# Altium schematic sheet BSPD_CMOS.SchDoc
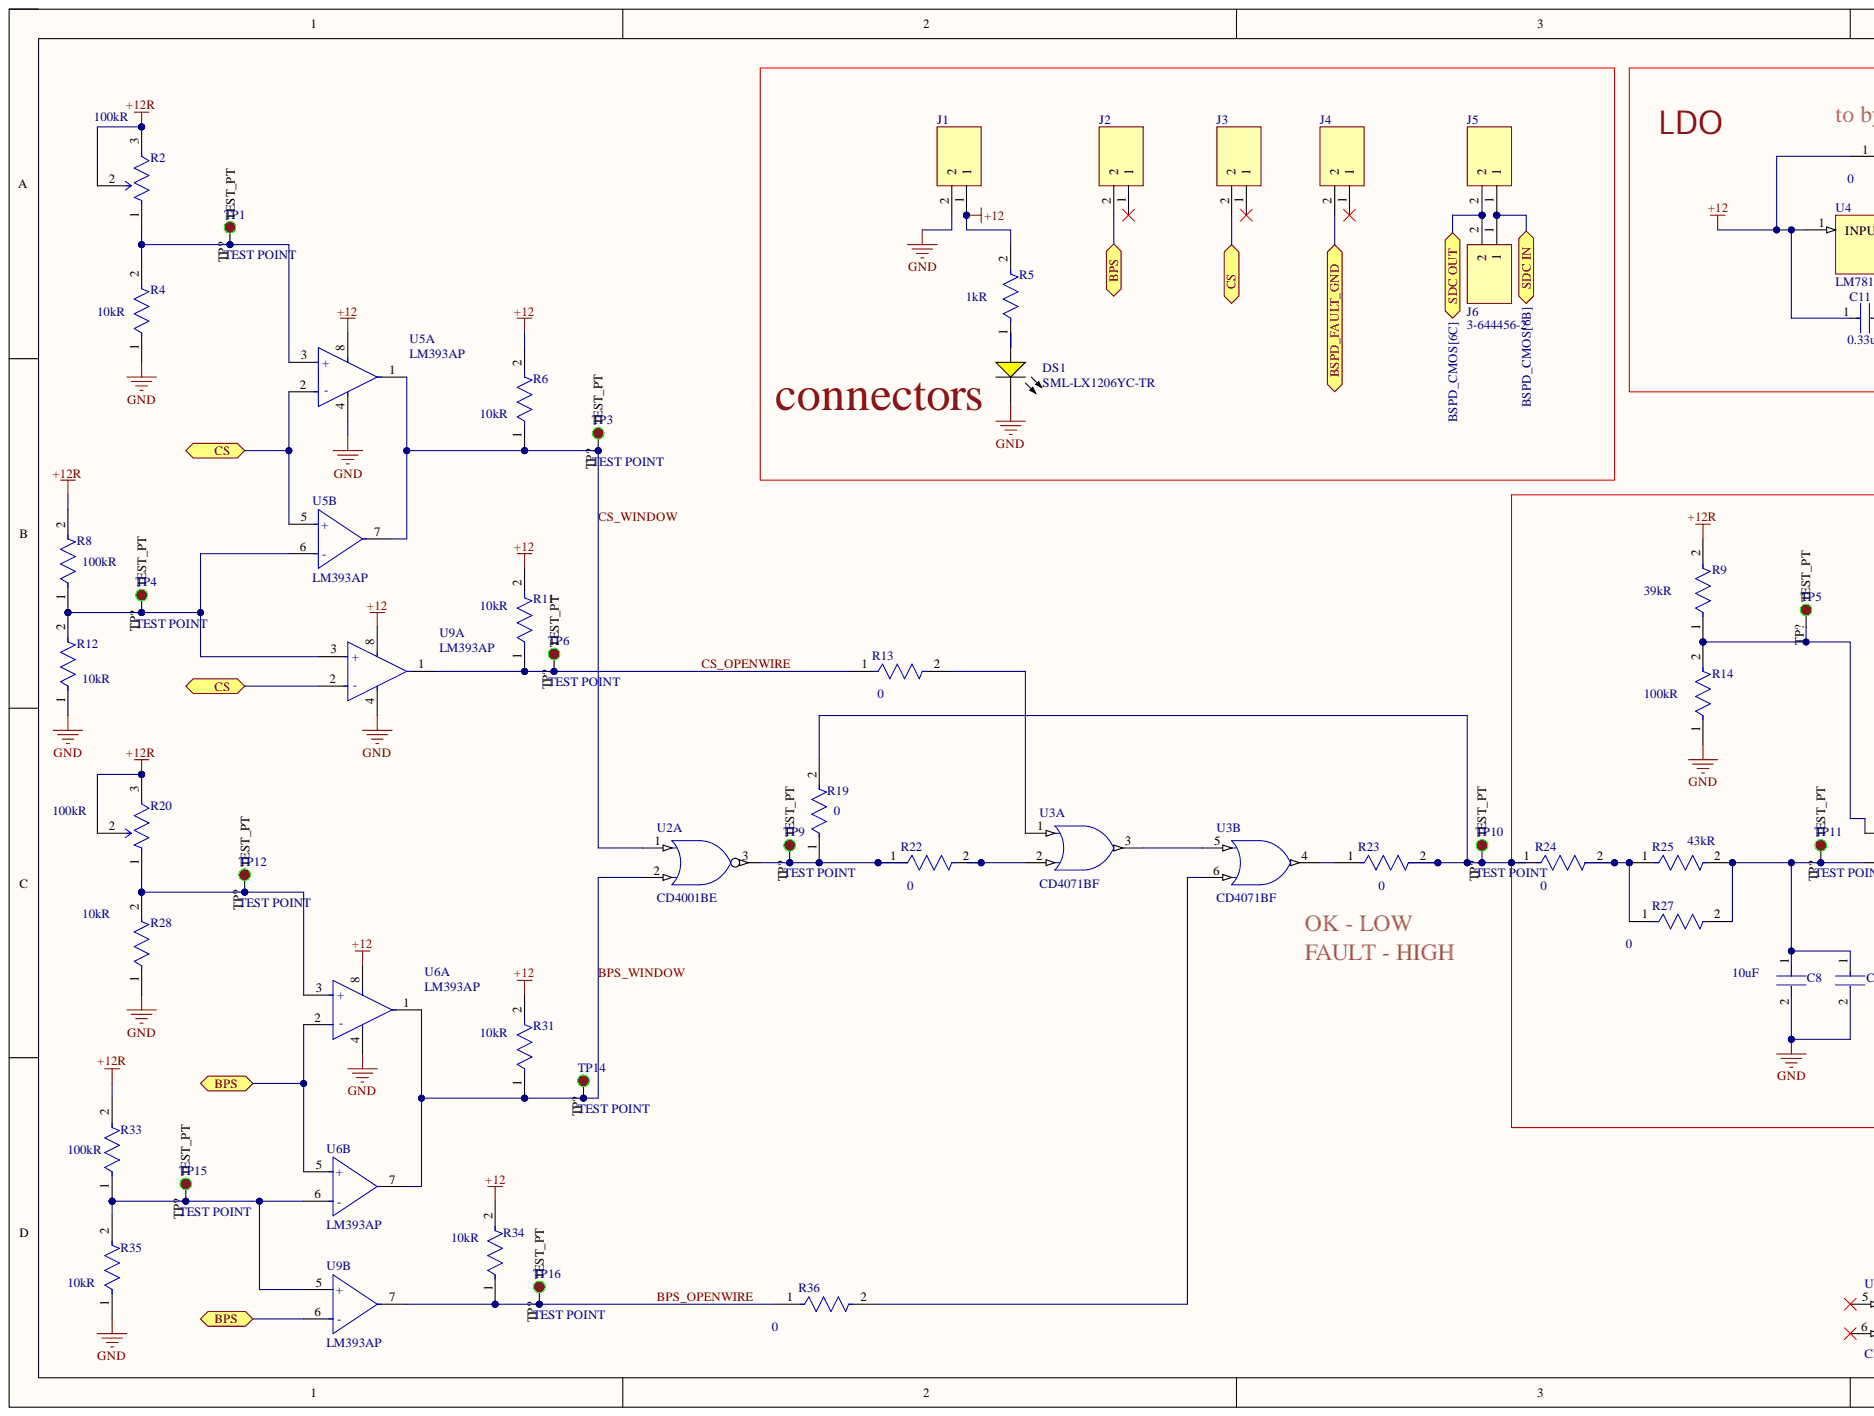
[diagram p0: BSPD_CMOS.SchDoc, left]
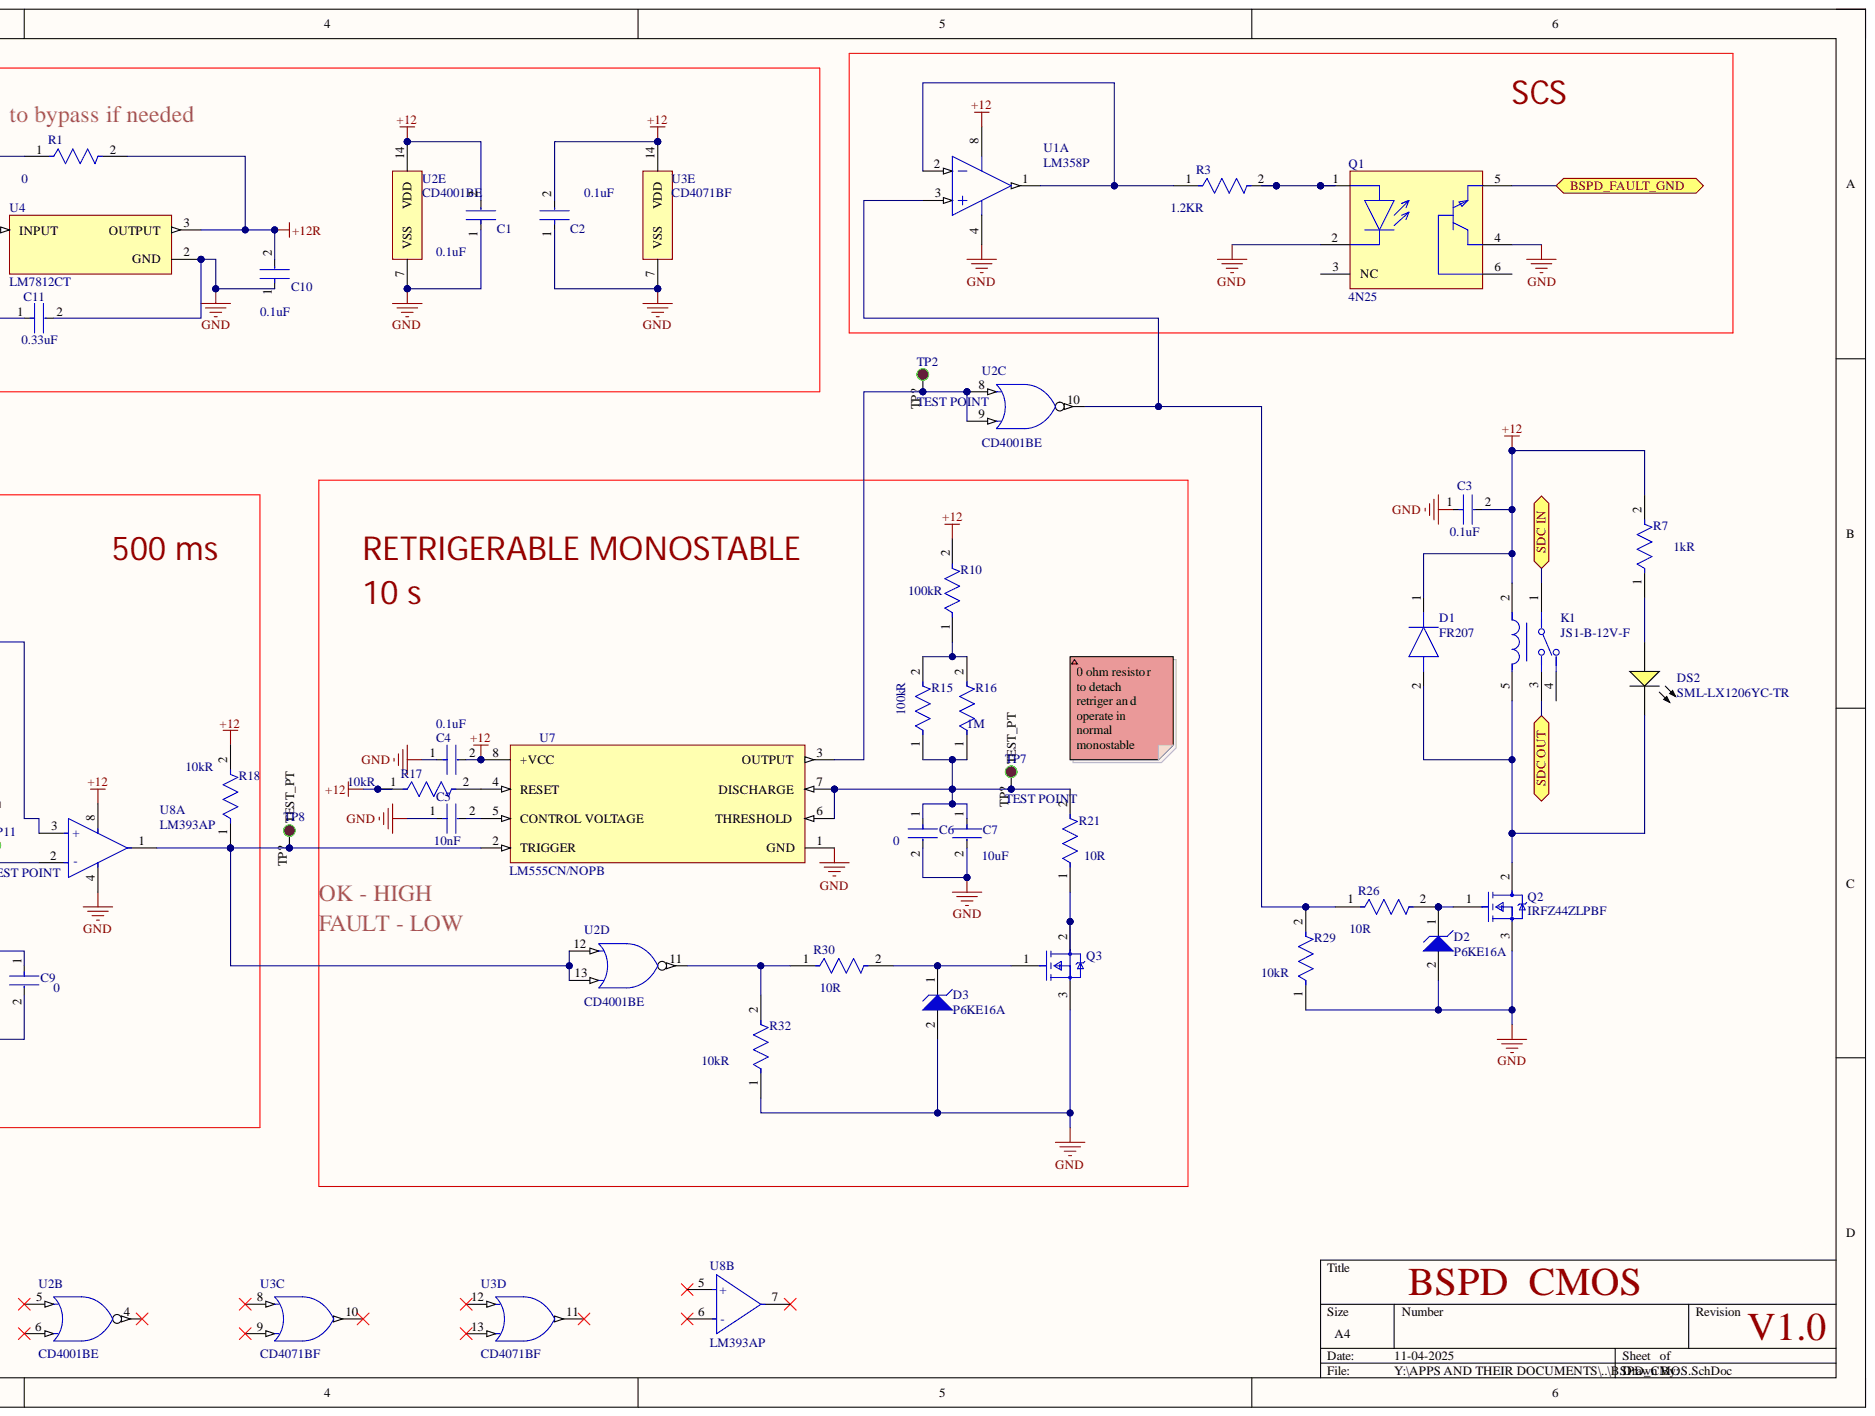
[diagram p1: BSPD_CMOS.SchDoc, right]

## Parts
C1  Capacitance: 0.1uF  [FP-0805-L_2_01_0_2-W_1_25-MFG]  (hidden comment: 08051C224K4T2A)
C2  Capacitance: 0.1uF  [FP-0805-L_2_01_0_2-W_1_25-MFG]  (hidden comment: 08051C224K4T2A)
C3  Capacitance: 0.1uF  [FP-0805-L_2_01_0_2-W_1_25-MFG]  (hidden comment: 08051C224K4T2A)
C4  Capacitance: 0.1uF  [FP-0805-L_2_01_0_2-W_1_25-MFG]  (hidden comment: 08051C224K4T2A)
C5  Capacitance: 10nF  [FP-0805-L_2_01_0_2-W_1_25-MFG]  (hidden comment: 08051C224K4T2A)
C6  08051C224K4T2A  [FP-0805-L_2_01_0_2-W_1_25-MFG]  (value not drawn)
C7  Capacitance: 10uF  [FP-0805-L_2_01_0_2-W_1_25-MFG]  (hidden comment: 08051C224K4T2A)
C8  Capacitance: 10uF  [FP-0805-L_2_01_0_2-W_1_25-MFG]  (hidden comment: 08051C224K4T2A)
C9  08051C224K4T2A  [FP-0805-L_2_01_0_2-W_1_25-MFG]  (value not drawn)
C10  Capacitance: 0.1uF  [FP-0805-L_2_01_0_2-W_1_25-MFG]  (hidden comment: 08051C224K4T2A)
C11  Capacitance: 0.33uF  [FP-0805-L_2_01_0_2-W_1_25-MFG]  (hidden comment: 08051C224K4T2A)
D1  FR207  [DIOAD1910W90L670D310]
D2  P6KE16A  [ONSC-SURMETIC40-2-017AA-01]
D3  P6KE16A  [ONSC-SURMETIC40-2-017AA-01]
DS1  SML-LX1206YC-TR  [LUMX-SML-LX1206YC-TR_V]
DS2  SML-LX1206YC-TR  [LUMX-SML-LX1206YC-TR_V]
J1  39-30-1020  [39-30-102Y]  (value not drawn)
J2  39-30-1020  [39-30-102Y]  (value not drawn)
J3  39-30-1020  [39-30-102Y]  (value not drawn)
J4  39-30-1020  [39-30-102Y]  (value not drawn)
J5  39-30-1020  [39-30-102Y]  (value not drawn)
J6  3-644456-2  [HDRV2W67P0X254_1X2_478X234X1029P]
K1  JS1-B-12V-F  [FP-JS1-B-12V-F-MFG]
Q1  4N25  [FP-4N25-MFG]
Q2  IRFZ44ZLPBF  [FP-PG-TO262-3-MFG]
Q3  IRFZ44ZLPBF  [FP-PG-TO262-3-MFG]
R1  MCU0805MD1003DP500  [FP-MCU0805-IPC_B]  (value not drawn)
R2  Resistance: 100kR  [FP-3386P-MFG]  (hidden comment: 3386P-1-104LF)
R3  Resistance: 1.2KR  [FP-MCU0805-IPC_B]  (hidden comment: MCU0805MD1003DP500)
R4  Resistance: 10kR  [FP-MCU0805-IPC_B]  (hidden comment: MCU0805MD1003DP500)
R5  Resistance: 1kR  [FP-MCU0805-IPC_B]  (hidden comment: MCU0805MD1003DP500)
R6  Resistance: 10kR  [FP-MCU0805-IPC_B]  (hidden comment: MCU0805MD1003DP500)
R7  Resistance: 1kR  [FP-MCU0805-IPC_B]  (hidden comment: MCU0805MD1003DP500)
R8  Resistance: 100kR  [FP-MCU0805-IPC_B]  (hidden comment: MCU0805MD1003DP500)
R9  Resistance: 39kR  [FP-MCU0805-IPC_B]  (hidden comment: MCU0805MD1003DP500)
R10  Resistance: 100kR  [FP-MCU0805-IPC_B]  (hidden comment: MCU0805MD1003DP500)
R11  Resistance: 10kR  [FP-MCU0805-IPC_B]  (hidden comment: MCU0805MD1003DP500)
R12  Resistance: 10kR  [FP-MCU0805-IPC_B]  (hidden comment: MCU0805MD1003DP500)
R13  MCU0805MD1003DP500  [FP-MCU0805-IPC_B]  (value not drawn)
R14  Resistance: 100kR  [FP-MCU0805-IPC_B]  (hidden comment: MCU0805MD1003DP500)
R15  Resistance: 100kR  [FP-MCU0805-IPC_B]  (hidden comment: MCU0805MD1003DP500)
R16  Resistance: 1M  [FP-MCU0805-IPC_B]  (hidden comment: MCU0805MD1003DP500)
R17  Resistance: 10kR  [FP-MCU0805-IPC_B]  (hidden comment: MCU0805MD1003DP500)
R18  Resistance: 10kR  [FP-MCU0805-IPC_B]  (hidden comment: MCU0805MD1003DP500)
R19  MCU0805MD1003DP500  [FP-MCU0805-IPC_B]  (value not drawn)
R20  Resistance: 100kR  [FP-3386P-MFG]  (hidden comment: 3386P-1-104LF)
R21  Resistance: 10R  [FP-MCU0805-IPC_B]  (hidden comment: MCU0805MD1003DP500)
R22  MCU0805MD1003DP500  [FP-MCU0805-IPC_B]  (value not drawn)
R23  MCU0805MD1003DP500  [FP-MCU0805-IPC_B]  (value not drawn)
R24  MCU0805MD1003DP500  [FP-MCU0805-IPC_B]  (value not drawn)
R25  Resistance: 43kR  [FP-MCU0805-IPC_B]  (hidden comment: MCU0805MD1003DP500)
R26  Resistance: 10R  [FP-MCU0805-IPC_B]  (hidden comment: MCU0805MD1003DP500)
R27  MCU0805MD1003DP500  [FP-MCU0805-IPC_B]  (value not drawn)
R28  Resistance: 10kR  [FP-MCU0805-IPC_B]  (hidden comment: MCU0805MD1003DP500)
R29  Resistance: 10kR  [FP-MCU0805-IPC_B]  (hidden comment: MCU0805MD1003DP500)
R30  Resistance: 10R  [FP-MCU0805-IPC_B]  (hidden comment: MCU0805MD1003DP500)
R31  Resistance: 10kR  [FP-MCU0805-IPC_B]  (hidden comment: MCU0805MD1003DP500)
R32  Resistance: 10kR  [FP-MCU0805-IPC_B]  (hidden comment: MCU0805MD1003DP500)
R33  Resistance: 100kR  [FP-MCU0805-IPC_B]  (hidden comment: MCU0805MD1003DP500)
R34  Resistance: 10kR  [FP-MCU0805-IPC_B]  (hidden comment: MCU0805MD1003DP500)
R35  Resistance: 10kR  [FP-MCU0805-IPC_B]  (hidden comment: MCU0805MD1003DP500)
R36  MCU0805MD1003DP500  [FP-MCU0805-IPC_B]  (value not drawn)
TP1  TEST POINT  [TEST_POINT]
TP2  TEST POINT  [TEST_POINT]
TP3  TEST POINT  [TEST_POINT]
TP4  TEST POINT  [TEST_POINT]
TP5  TEST POINT  [TEST_POINT]
TP6  TEST POINT  [TEST_POINT]
TP7  TEST POINT  [TEST_POINT]
TP8  TEST POINT  [TEST_POINT]
TP9  TEST POINT  [TEST_POINT]
TP10  TEST POINT  [TEST_POINT]
TP11  TEST POINT  [TEST_POINT]
TP12  TEST POINT  [TEST_POINT]
TP14  TEST POINT  [TEST_POINT]
TP15  TEST POINT  [TEST_POINT]
TP16  TEST POINT  [TEST_POINT]
U1  LM358P  [P0008A]  (part A)
U2  CD4001BE  [N0014A]  (parts A,B,C,D,E)
U3  CD4071BF  [J0014A]  (parts A,B,C,D,E)
U4  LM7812CT  [FP-TO220B03-MFG]
U5  LM393AP  [FP-P0008A-MFG]  (parts A,B)
U6  LM393AP  [FP-P0008A-MFG]  (parts A,B)
U7  LM555CN/NOPB  [FP-P0008A-MFG]
U8  LM393AP  [FP-P0008A-MFG]  (parts A,B)
U9  LM393AP  [FP-P0008A-MFG]  (parts A,B)

## Ports
BPS, BSPD_FAULT_GND, CS, SDC IN, SDC OUT

## Nets
+12: C1.2 C2.2 C3.2 C4.2 C11.1 D1.1 J1.1 K1.2 R1.1 R5.2 R6.2 R7.2 R10.2 R11.2 R17.1 R18.2 R31.2 R34.2 U1.8 U2.14(VDD) U3.14(VDD) U4.1 U5.8 U6.8 U7.8(+VCC) U8.8 U9.8
+12R: C10.2 R1.2 R2.2 R2.3 R8.2 R9.2 R20.2 R20.3 R33.2 U4.3
GND: C1.1 C2.1 C3.1 C4.1 C5.1 C6.2 C7.2 C8.2 C9.2 C10.1 C11.2 D2.2 D3.2 DS1.2 J1.2 Q1.2 Q1.4 Q2.3 Q3.3 R4.1 R12.1 R14.1 R28.1 R29.1 R32.1 R35.1 U1.4 U2.7(VSS) U3.7(VSS) U4.2 U5.4 U6.4 U7.1(GND) U8.4 U9.4
BPS: J2.2 U6.2(-) U6.5(+) U9.6(-) ; port BPS
BPS_OPENWIRE: R34.1 R36.1 TP16.TP? U9.7
BPS_WINDOW: R31.1 TP14.TP? U2.2 U6.1 U6.7
BSPD_FAULT_GND: J4.2 Q1.5 ; port BSPD_FAULT_GND
CS: J3.2 U5.2(-) U5.5(+) U9.2(-) ; port CS
CS_OPENWIRE: R11.1 R13.1 TP6.TP? U9.1
CS_WINDOW: R6.1 TP3.TP? U2.1 U5.1 U5.7
SDC IN: J5.1 J6.1 K1.1 ; port SDC IN
SDC OUT: J5.2 J6.2 K1.3 ; port SDC OUT
NetC5_2: C5.2 U7.5(CONTROL_VOLTAGE)
NetC6_1: C6.1 C7.1 R15.1 R16.1 R21.2 TP7.TP? U7.6(THRESHOLD) U7.7(DISCHARGE)
NetC8_1: C8.1 C9.1 R25.2 R27.2 TP11.TP? U8.2(-)
NetD1_2: D1.2 DS2.2 K1.5 Q2.2
NetD2_1: D2.1 Q2.1 R26.2
NetD3_1: D3.1 Q3.1 R30.2
NetDS1_1: DS1.1 R5.1
NetDS2_1: DS2.1 R7.1
NetQ1_1: Q1.1 R3.2
NetQ3_2: Q3.2 R21.1
NetR2_1: R2.1 R4.2 TP1.TP? U5.3(+)
NetR3_1: R3.1 U1.1 U1.2
NetR8_1: R8.1 R12.2 TP4.TP? U5.6(-) U9.3(+)
NetR9_1: R9.1 R14.2 TP5.TP? U8.3(+)
NetR10_1: R10.1 R15.2 R16.2
NetR13_2: R13.2 U3.1
NetR17_2: R17.2 U7.4(RESET)
NetR18_1: R18.1 TP8.TP? U2.12 U2.13 U7.2(TRIGGER) U8.1
NetR19_1: R19.1 R22.1 TP9.TP? U2.3
NetR19_2: R19.2 R23.2 R24.1 TP10.TP?
NetR20_1: R20.1 R28.2 TP12.TP? U6.3(+)
NetR22_2: R22.2 U3.2
NetR23_1: R23.1 U3.4
NetR24_2: R24.2 R25.1 R27.1
NetR26_1: R26.1 R29.2 U1.3 U2.10
NetR30_1: R30.1 R32.2 U2.11
NetR33_1: R33.1 R35.2 TP15.TP? U6.6(-) U9.5(+)
NetR36_2: R36.2 U3.6
NetTP2_TP?: TP2.TP? U2.8 U2.9 U7.3(OUTPUT)
NetU3_3: U3.3 U3.5

Not connected: J2 1; J3 1; J4 1; K1 4; Q1 3(NC) 6; U2 4 5 6; U3 8 9 10 11 12 13; U8 5(+) 6(-) 7

## Library data of the parts
C1-C5 C7 C8 C10 C11 = CMP-04427-012849-1: Automotive Ceramic Capacitor, 0805, 220nF, 10%, X7R, 15%, 100V; Case/Package: 0805; Component Kind: Standard; Contact Plating: Tin; Dielectric: X7R; Length: 2.01mm; Library Name: Altium Content Vault; Max Operating Temperature: 125°C; Min Operating Temperature: -55°C; Mount: Surface Mount; RoHS Compliant: Yes; Termination: Wraparound; Tolerance: 10%; Voltage Rating (DC): 100V
C6 C9 = CMP-04427-012849-1: Automotive Ceramic Capacitor, 0805, 220nF, 10%, X7R, 15%, 100V; Capacitance: 0; Case/Package: 0805; Component Kind: Standard; Contact Plating: Tin; Dielectric: X7R; Length: 2.01mm; Library Name: Altium Content Vault; Max Operating Temperature: 125°C; Min Operating Temperature: -55°C; Mount: Surface Mount; RoHS Compliant: Yes; Termination: Wraparound; Tolerance: 10%; Voltage Rating (DC): 100V
D1 = FR207: Diode; Manufacturer_Name: Rectron; Arrow Part Number: FR207; Arrow Price/Stock: https://www.arrow.com/en/products/fr207/rectron?region=nac; Component Kind: Standard; Datasheet Link: https://www.arrow.com/en/products/fr207/rectron; Manufacturer_Part_Number: FR207
D2 D3 = CMP-1061-00713-1: 600 W Peak Power Transient Voltage Suppressor, 2-Pin Surmetic(TM) 40, Bulk Box; Manufacturer: On Semiconductor; Direction: Unidirectional; IR Max (uA): 5; PPK Max (W): 600; V(BR) Typ (V): 16; Component Kind: Standard; ComponentLink1Description: Manufacturer URL; ComponentLink1URL: http://www.onsemi.com/; ComponentLink2Description: Datasheet; ComponentLink2URL: http://www.onsemi.com/pub_link/Collateral/P6KE6.8A-D.PDF; ComponentLink3Description: Package Specification; ComponentLink3URL: http://www.onsemi.cn/pub_link/Collateral/017AA.PDF; DatasheetVersion: Rev. 10; Library Name: Altium Content Vault; Mounting Technology: Through Hole; PackageDescription: 2-Pin, Axial Lead, Diameter 3.49 mm; PackageReference: SURMETIC40-2-017AA-01; PackageVersion: Rev. O, 09/2005; Packing: Bulk Box; PartNumber: P6KE16A
DS1 DS2 = CMP-2000-07124-1: Yellow LED, Water Clear Len, 585 nm, 2.1 V, -40 to 85 degC, 2-Pin SMD, RoHS, Tape and Reel; Manufacturer: Lumex; Catalog Drawings: SML-LX1206SeriesTopSML-LX1206SeriesSideSML-LX1206SeriesBottomSML-LX1206SeriesFootprint; Current - Test: 20mA; Lens Color: Colorless; Lens Style/Size: RectanglewithFlatTop; Millicandela Rating: 6mcd; Online Catalog: SML-LX1206RectanglewithFlatTop1206PackageLEDs; Other Names: 67-1358-2LU60357TRSMLLX1206YCTR; Product Training Modules: LEDsBenefitsofCustomLEDandLCDTechnology; Viewing Angle: 160°; Voltage - Forward (Vf) (Typ): 2.1V; Wavelength - Peak: 585nm; Category: Optoelectronics; Color: Yellow; Component Kind: Standard; Datasheet URL: http://www.lumex.com/content/files/ProductAttachment/SML-LX1206YC-TR.pdf; Datasheet Version: Rev. K, 08/2012; Family: LEDIndication-Discrete; Features: -; Height (Max): 1.10mm; Lens Transparency: Clear; Library Name: Altium Content Vault; Manufacturer URL: http://www.lumexinstruments.com/; Mounting Technology: SurfaceMount; Mounting Type: SurfaceMount; Package / Case: 1206(3216Metric); Package Description: 2-Pin Surface Mount Device, Body 3.2 x 1.5 mm; Package Reference: SML-LX1206YC-TR; Packaging: Tape&Reel(TR); RoHS Information: RoHSCertofCompliance; Series: -; Size / Dimension: 3.20mmLx1.60mmW; Standard Package: 3,000; Supplier Device Package: 1206; Wavelength - Dominant: -
J1-J5 = 39-30-1020: Connector; Manufacturer_Name: Molex; Component Kind: Standard; Datasheet Link: https://www.molex.com/pdm_docs/sd/039300020_sd.pdf; Height: 10mm; Manufacturer_Part_Number: 39-30-1020; Mouser Part Number: 538-39-30-1020; Mouser Price/Stock: https://www.mouser.co.uk/ProductDetail/Molex/39-30-1020?qs=tRPrwvvr%2Fuj45jNVCpHEJA%3D%3D
J6 = 3-644456-2: Connector; Manufacturer_Name: TE Connectivity; Arrow Part Number: 3-644456-2; Arrow Price/Stock: https://www.arrow.com/en/products/3-644456-2/te-connectivity?utm_currency=USD&region=nac; Component Kind: Standard; Datasheet Link: https://www.te.com/commerce/DocumentDelivery/DDEController?Action=showdoc&DocId=Customer+Drawing%7F644456%7FD2%7Fpdf%7FEnglish%7FENG_CD_644456_D2.pdf%7F3-644456-2; Height: 10.287mm; Manufacturer_Part_Number: 3-644456-2; Mouser Part Number: 571-3-644456-2; Mouser Price/Stock: https://www.mouser.co.uk/ProductDetail/TE-Connectivity/3-644456-2?qs=AplfTeSvkkCnnz4NxZTwTQ%3D%3D
K1 = CMP-05607-000050-1: RELAY GEN PURPOSE SPDT 10A 12V; Coil Current: 30mA; Coil Power: 360mW; Coil Resistance: 400R; Coil Type: Non-Latching; Max Voltage Rating (AC): 250V; Max Voltage Rating (DC): 100V; Operate Time: 10ms; Relay Type: General Purpose; Coil Voltage (DC): 12V; Component Kind: Standard; Contact Current Rating: 10A; Contact Material: Tin; Depth: 16mm; Height: 16mm; Lead Free: Lead Free; Length: 22mm; Library Name: Altium Content Vault; Max Operating Temperature: 85°C; Min Operating Temperature: -40°C; Mount: Through Hole; Number of Pins: 5; Package Quantity: 100; Packaging: Bulk; Power Consumption: 360mW; Power Rating: 360mW; Release Time: 10ms; Series: JS; Termination: PC Pin; Throw Configuration: SPDT; Voltage Rating (AC): 250V; Width: 22mm
Q1 = CMP-22018-000009-1: OPTOISO 2.5KV TRANS W/BASE 6DIP; Collector Emitter Breakdown Voltage: 30V; Collector Emitter Saturation Voltage: 500mV; Current Transfer Ratio: 50%; Fall Time: 2us; Forward Current: 60mA; Forward Voltage: 1.5V; Input Current: 50mA; Input Type: DC; Isolation Voltage: 5kV; Max Collector Current: 100mA; Max Input Current: 80mA; Max Power Dissipation: 250mW; Number of Channels: 1; Output Voltage: 70V; Power Dissipation: 150mW; Reverse Breakdown Voltage: 5V; Case/Package: PDIP; Collector Emitter Voltage (VCEO): 30V; Collector-emitter Voltage-Max: 500mV; Component Kind: Standard; Isolation Voltage-Max: 5kV; Lead Free: Lead Free; Library Name: Altium Content Vault; Max Operating Temperature: 100°C; Max Output Voltage: 30V; Min Operating Temperature: -55°C; Mount: Through Hole; Number of Circuits: 1; Number of Elements: 1; Number of Pins: 6; Output Current per Channel: 100mA; Package Quantity: 2000; Packaging: Rail/Tube; Radiation Hardening: No; Rise Time: 2us; Series: -
Q2 Q3 = CMP-32901-000428-1: MOSFET N-CH 55V 51A TO262; Drain to Source Resistance: 13.9mR; Element Configuration: Single; Gate to Source Voltage (Vgs): 20V; Input Capacitance: 1.42nF; Max Power Dissipation: 80W; Turn-Off Delay Time: 33ns; Turn-On Delay Time: 14ns; Case/Package: TO-262; Component Kind: Standard; Continuous Drain Current (ID): 51A; Drain to Source Voltage (Vdss): 55V; Halogen Free: Halogen Free; Height: 0.355inch; Lead Free: Contains Lead; Length: 0.419inch; Library Name: Altium Content Vault; Max Dual Supply Voltage: 55V; Max Operating Temperature: 175°C; Min Operating Temperature: -55°C; Mount: Through Hole; Number of Pins: 3; Packaging: Rail/Tube; Power Dissipation: 80W; Rds On Max: 13.9mR; RoHS Compliant: Yes; Width: 0.19inch
R1 R13 R19 R22-R24 R27 R36 = CMP-02407-011860-1: RES 1.05K OHM 0.5% 1/5W 0805; Max Power Dissipation: 200mW; Resistance: 0; Temperature Coefficient: 25ppm/°C; Voltage Rating: 150V; Case Code (Imperial): 0805; Case Code (Metric): 2012; Case/Package: 0805; Component Kind: Standard; Features: Automotive AEC-Q200; Height: 0.024inch; Length: 0.079inch; Library Name: Altium Content Vault; Max Operating Temperature: 175°C; Min Operating Temperature: -55°C; Number of Terminations: 2; Package Quantity: 1; Packaging: Tape and Reel; Power Rating: 200mW; Resistor Type: Thin Film; RoHS Compliant: Yes; Series: MCU 0805 - Professional; Tolerance: 0.5%; Weight: 0.000162oz; Width: 1.25mm
R2 R20 = CMP-2000-05592-8: TRIMMER 100K OHM 0.5W PC PIN TOP; Manufacturer Series: 3386; Actuator Orientation: Vertical; Actuator Type: SCREW; Max Power Dissipation: 500mW; Number of Terminals/Pins: 3; Number of Turns: 1; Resistance Law: Linear; Resistance Tolerance: 10%; Temperature Coefficient: 100ppm/°C; Voltage Rating: 300V; Color: Blue; Component Kind: Standard; Contact Plating: Tin; Depth: 9.53mm; Height: 4.83mm; Lead Free: Contains Lead; Length: 9.53mm; Library Name: Altium Content Vault; Max Operating Temperature: 125°C; Military Standard: Not; Min Operating Temperature: -55°C; Mount: Through Hole; Number of Pins: 3; Package Quantity: 100; Packaging: Rail/Tube; Power Rating: 500mW; Radiation Hardening: No; Reach SVHC Compliant: Yes; Resistor Type: Cermet; RoHS Compliant: Yes; Series: Trimpot® 3386 - Sealed; Taper: Linear; Technology: CERMET; Terminal Finish: Tin; Termination: Pin; Tolerance: 10%; Type: Potentiometer; Weight: 0.03oz; Width: 9.53mm
R3-R12 R14-R18 R21 R25 R26 R28-R35 = CMP-02407-011860-1: RES 1.05K OHM 0.5% 1/5W 0805; Max Power Dissipation: 200mW; Temperature Coefficient: 25ppm/°C; Voltage Rating: 150V; Case Code (Imperial): 0805; Case Code (Metric): 2012; Case/Package: 0805; Component Kind: Standard; Features: Automotive AEC-Q200; Height: 0.024inch; Length: 0.079inch; Library Name: Altium Content Vault; Max Operating Temperature: 175°C; Min Operating Temperature: -55°C; Number of Terminations: 2; Package Quantity: 1; Packaging: Tape and Reel; Power Rating: 200mW; Resistor Type: Thin Film; RoHS Compliant: Yes; Series: MCU 0805 - Professional; Tolerance: 0.5%; Weight: 0.000162oz; Width: 1.25mm
TP1-TP12 TP14-TP16 = TEST_POINT: Component Kind: Standard; Library Name: TEST_POINT.SchLib; VALUE: TEST POINT
U1 = CMP-2000-06549-1: Dual Operational Amplifier, 3 to 32 V, 0 to 70 degC, 8-Pin PDIP (P), RoHS, Tape and Reel; Manufacturer: Technik Industrial; Component Kind: Standard; Datasheet URL: http://www.ti.com/lit/ds/symlink/lm358.pdf; Datasheet Version: Rev. T, 04/2015; Library Name: Altium Content Vault; Manufacturer URL: http://www.technik.com.hk/; Mounting Technology: ThroughHole; Package Description: 8-Pin Double In-line Package, Body 9.59 x 6.35 mm, Pitch 2.54 mm; Package Reference: P8; Package URL: http://www.ti.com/lit/ml/mpdi001b/mpdi001b.pdf
U2 = CMP-0806-00193-3: CMOS Quad 2-Input NOR Gate, N0014A, TUBE; Manufacturer: Texas Instruments; Bits(#): 4; F @ Nom Voltage(Max)(Mhz): 8; ICC @ Nom Voltage(Max)(mA): 0.015; Output Drive (IOL/IOH)(Max)(mA): 1.5/-1.5; Rating: Military; tpd @ Nom Voltage(Max)(ns): 120; VCC(Max)(V): 18; VCC(Min)(V): 3; Voltage(Nom)(V): 10; Code_JEDEC: MS-001; Component Kind: Standard; DatasheetVersion: SCHS015C; Generic Part Number: CD4001B; Input Type: CMOS; Library Name: Altium Content Vault; Operating Temperature Range(C): -55 to 125; Output Type: CMOS; PackageReference: N0014A; PackageVersion: http://www.ti.com/lit/ml/mpdi002c/mpdi002c.pdf
U3 = CMP-1573-00122-3: CMOS Quad 2-Input OR Gate, J0014A, TUBE; Generic Part Number: CD4071B-MIL; Manufacturer: Texas Instruments; Bits(#): 4; F @ Nom Voltage(Max)(Mhz): 8; ICC @ Nom Voltage(Max)(mA): 0.015; Output Drive (IOL/IOH)(Max)(mA): 1.5/-1.5; Rating: Military; tpd @ Nom Voltage(Max)(ns): 250; VCC(Max)(V): 18; VCC(Min)(V): 3; Voltage(Nom)(V): 10; Component Kind: Standard; DatasheetVersion: SCHS056D; Input Type: CMOS; Library Name: Altium Content Vault; Operating Temperature Range(C): -55 to 125; Output Type: CMOS; PackageReference: J0014A; PackageVersion: http://www.ti.com/lit/ml/mcer002d/mcer002d.pdf
U4 = CMP-07128-000015-1: IC REG LINEAR 12V 1A TO220-3; Manufacturer: ON Semiconductor; Obsolete Part: true; Accuracy Percentage: 4%; Dropout Voltage: 2V; Max Supply Voltage: 35V; Nominal Supply Current: 5.1mA; Number Of Outputs: 1; Output Type: Fixed; Standoff Height: 2.7mm; Automotive: No; Category: IC; Component Kind: Standard; ComponentLink2Description: Datasheet; ComponentLink2URL: https://www.mouser.in/datasheet/2/308/LM7812-1125088.pdf; Device Class L1: Integrated Circuits (ICs); Device Class L2: Power Management ICs; Device Class L3: Voltage Regulators - Linear; Digikey Description: IC REG LINEAR 12V 1A TO220-3; Height: 20.4mm; Ipc Land Pattern Name: TO-220; Lead Free: Yes; Library Name: Altium Content Vault; Manufacturer Part Number: LM7812CT; Octopart: https://octopart.com/lm7812ct-on+semiconductor-14383031; Output Current: 1A; Output Voltage: 12V; Package: TO220B03; RoHS: TRUE; Temperature: -40°C to +125°C; Temperature Range High: +125°C; Temperature Range Low: -40°C
U5 U6 U8 U9 = CMP-04896-000062-1: IC DUAL DIFF COMPARATOR 8-DIP; Input Bias Current: 250nA; Input Offset Voltage (Vos): 2mV; Max Dual Supply Voltage: 18V; Max Supply Current: 500uA; Max Supply Voltage: 30V; Min Dual Supply Voltage: 1V; Min Supply Voltage: 2V; Nominal Supply Current: 1mA; Number of Channels: 2; Output Current: 20mA; Output Current per Channel: 6mA; Output Type: TTL; Quiescent Current: 2.5mA; Response Time: 0.3us; Voltage Gain: 106.02dB; Case/Package: PDIP; Component Kind: Standard; Contact Plating: Gold; Lead Free: Lead Free; Library Name: Altium Content Vault; Max Input Current: 250nA; Max Operating Temperature: 70°C; Min Operating Temperature: 0°C; Mount: Through Hole; Number of Elements: 2; Number of Pins: 8; Package Quantity: 50; Packaging: Rail/Tube; Radiation Hardening: No; REACH SVHC: No SVHC; RoHS Compliant: Yes; Type: General Purpose; Weight: 0.015535oz
U7 = CMP-0056-00016-4: IC OSC SINGLE TIMER 100KHZ 8-DIP; Manufacturer: Texas Instruments; Max Junction Temp: +70°C; Max Supply Voltage: 16V; Min Supply Voltage: 4.5V; Nominal Supply Current: 3mA; Number Of Timers/Counters: 1; Standoff Height: 0.51mm; Ambient Temperature Range High: +70°C; Ambient Temperature Range Low: 0°C; Automotive: No; Category: IC; Component Kind: Standard; ComponentLink2Description: Datasheet; ComponentLink2URL: http://www.ti.com/lit/ds/symlink/lm555.pdf; Device Class L1: Integrated Circuits (ICs); Device Class L2: Clock and Timing; Device Class L3: Timers and Oscillators; Digikey Description: IC OSC SINGLE TIMER 100KHZ 8-DIP; Height: 5.08mm; Lead Free: Yes; Library Name: Altium Content Vault; Manufacturer Part Number: LM555CN/NOPB; Octopart: https://octopart.com/lm555cn%2Fnopb-texas+instruments-24814897; Package: P0008A; RoHS: TRUE; Temperature: 0°C to +70°C; Temperature Range High: +70°C; Temperature Range Low: 0°C
